# Altium schematic sheet RF_sheet.SchDoc
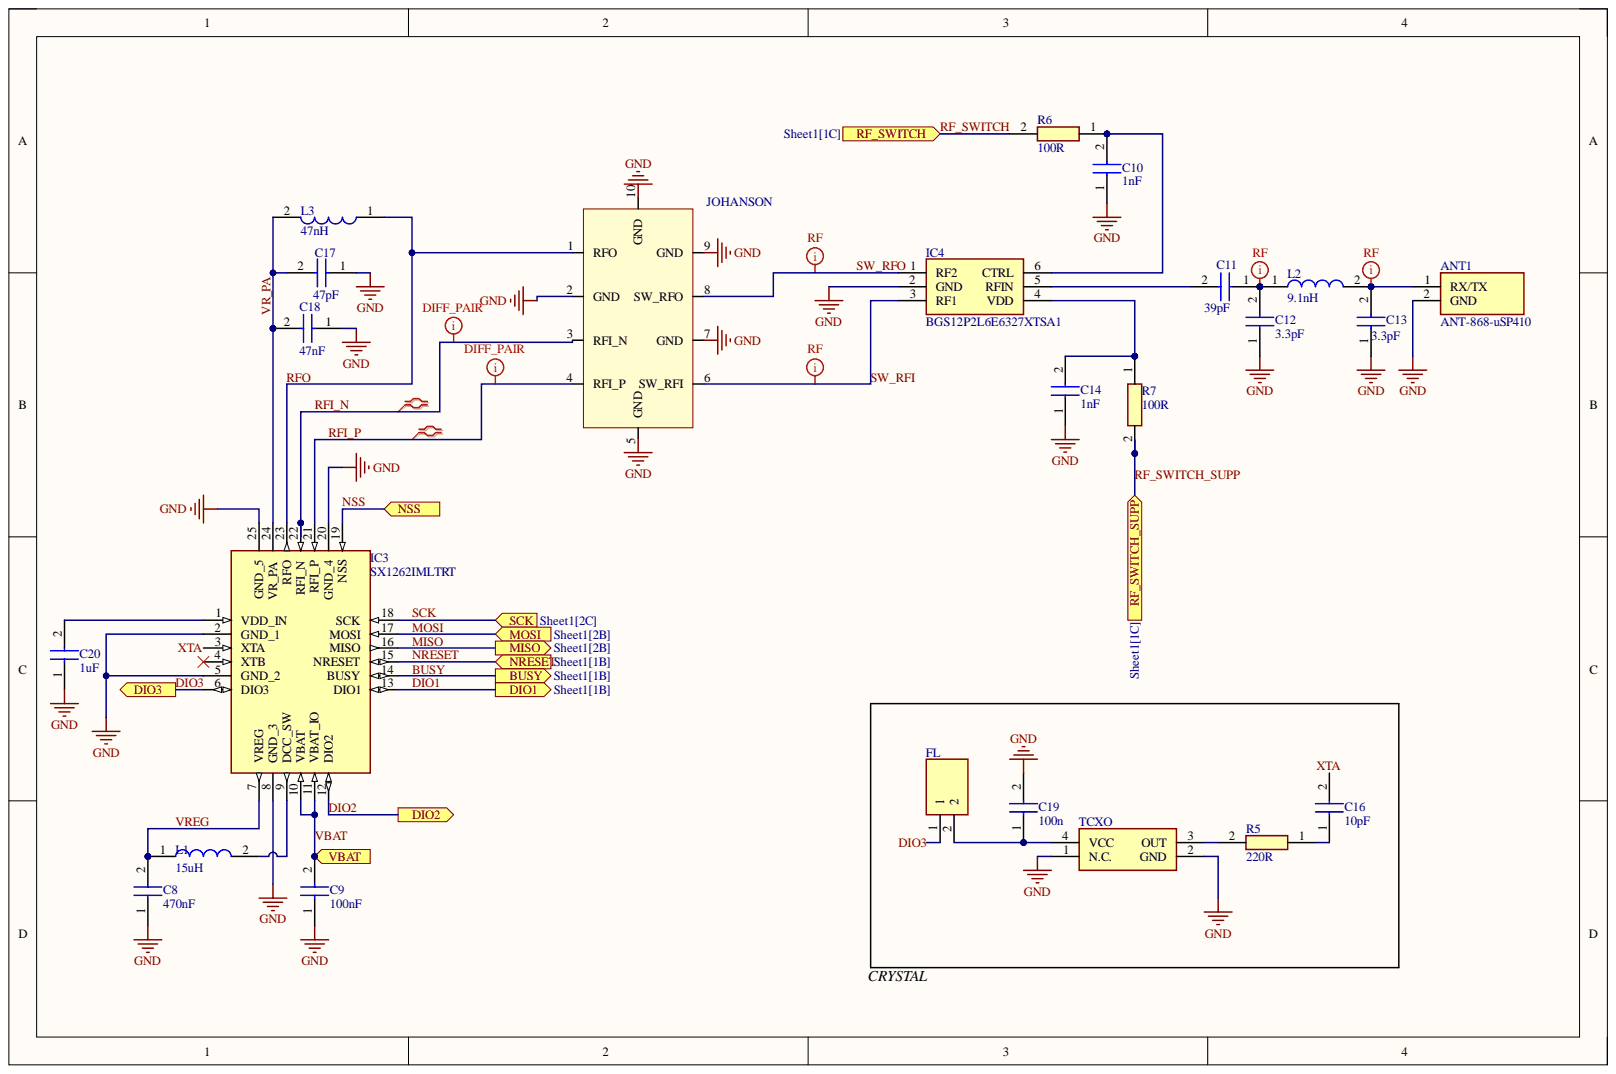
[diagram p0: RF_sheet.SchDoc]

## Parts
ANT1  ANT-868-uSP410  [ANT868uSP410]
C8  470nF  [CAPC1005X55N]
C9  100nF  [CAPC1005X55N]
C10  1nF  [CAPC1005X55N]
C11  39pF  [CAPC1005X55N]
C12  3.3pF  [CAPC1005X55N]
C13  3.3pF  [CAPC1005X55N]
C14  1nF  [CAPC1005X55N]
C16  10pF  [CAPC1005X55N]
C17  47pF  [CAPC1005X55N]
C18  47nF  [CAPC1005X55N]
C19  100n  [CAPC1005X55N]
C20  1uF  [CAPC1608X90N]
FL  BLM18PG181SN1D  [BLM18AG102BH1D]  (value not drawn)
IC3  SX1262IMLTRT  [QFN50P400X400X100-25N-D]
IC4  BGS12P2L6E6327XTSA1  [BGS12P2L6E6327XTSA1]
JOHANSON  (no value)  [JOHANSON]
L1  15uH  [INDC1608X55N]
L2  9.1nH  [INDC1005X37N]
L3  47nH  [INDC1005X37N]
R5  220R  [RESC1005X35N]
R6  100R  [RESC1005X35N]
R7  100R  [RESC1005X35N]
TCXO  TG2520SMN_32.0000M-ECGNNM0  [TG2520SMN320000MECGNNM0]  (value not drawn)

## Ports
BUSY, DIO1, DIO2, DIO3, MISO, MOSI, NRESET, NSS, RF_SWITCH, RF_SWITCH_SUPP, SCK, VBAT

## Nets
GND: ANT1.2 C8.1 C9.1 C10.1 C12.1 C13.1 C14.1 C17.1 C18.1 C19.2 C20.1 IC3.2(GND_1) IC3.5(GND_2) IC3.8(GND_3) IC3.20(GND_4) IC3.25(GND_5) IC4.2(GND) JOHANSON.2(GND) JOHANSON.5(GND) JOHANSON.7(GND) JOHANSON.9(GND) JOHANSON.10(GND) TCXO.1(N.C.) TCXO.2(GND)
BUSY: IC3.14(BUSY) ; port BUSY
DIO1: IC3.13(DIO1) ; port DIO1
DIO2: IC3.12(DIO2) ; port DIO2
DIO3: FL.1 IC3.6(DIO3) ; port DIO3
MISO: IC3.16(MISO) ; port MISO
MOSI: IC3.17(MOSI) ; port MOSI
NRESET: IC3.15(NRESET) ; port NRESET
NSS: IC3.19(NSS) ; port NSS
RF_SWITCH: R6.2 ; port RF_SWITCH
RF_SWITCH_SUPP: R7.2 ; port RF_SWITCH_SUPP
RFI_N: IC3.22(RFI_N) JOHANSON.3(RFI_N)
RFI_P: IC3.21(RFI_P) JOHANSON.4(RFI_P)
RFO: IC3.23(RFO) JOHANSON.1(RFO) L3.1
SCK: IC3.18(SCK) ; port SCK
SW_RFI: IC4.3(RF1) JOHANSON.6(SW_RFI)
SW_RFO: IC4.1(RF2) JOHANSON.8(SW_RFO)
VBAT: C9.2 IC3.10(VBAT) IC3.11(VBAT_IO) ; port VBAT
VR_PA: C17.2 C18.2 IC3.24(VR_PA) L3.2
VREG: C8.2 IC3.7(VREG) L1.1
XTA: C16.2 IC3.3(XTA)
NetANT1_1: ANT1.1 C13.2 L2.2
NetC10_2: C10.2 IC4.6(CTRL) R6.1
NetC11_1: C11.1 C12.2 L2.1
NetC11_2: C11.2 IC4.5(RFIN)
NetC14_2: C14.2 IC4.4(VDD) R7.1
NetC16_1: C16.1 R5.1
NetC19_1: C19.1 FL.2 TCXO.4(VCC)
NetC20_2: C20.2 IC3.1(VDD_IN)
NetIC3_9: IC3.9(DCC_SW) L1.2
NetR5_2: R5.2 TCXO.3(OUT)

Not connected: IC3 4(XTB)

## Library data of the parts
ANT1 = ANT-868-uSP410: Antenna; Manufacturer_Name: Linx Technologies; Component Kind: Standard; Datasheet Link: https://www.mouser.fr/datasheet/2/238/ant_868_usp410_ds-1892243.pdf; Height: 2.9mm; Manufacturer_Part_Number: ANT-868-uSP410; Mouser Part Number: 712-ANT-868-USP410; Mouser Price/Stock: https://www.mouser.co.uk/ProductDetail/Linx-Technologies/ANT-868-uSP410?qs=hWgE7mdIu5R%252BzZ8bzGhbRQ%3D%3D
C8-C14 C16-C19 = GRM155R61A224KE19D: 0402 Capacitor; Manufacturer_Name: Murata Electronics; Arrow Part Number: GRM155R61A224KE19D; Arrow Price/Stock: https://www.arrow.com/en/products/grm155r61a224ke19d/murata-manufacturing?region=nac; Component Kind: Standard; Datasheet Link: https://datasheet.datasheetarchive.com/originals/distributors/Datasheets_SAMA/ec4f1577dddcc7a13ddad897a28002d3.pdf; Height: 0.55mm; Manufacturer_Part_Number: GRM155R61A224KE19D; Mouser Part Number: 81-GRM155R61A224KE19; Mouser Price/Stock: https://www.mouser.co.uk/ProductDetail/Murata-Electronics/GRM155R61A224KE19D?qs=5bAuhL3RhmP2%252BUJqdGBuag%3D%3D
C20 = 06035C101K4T2A: 0603 Capacitor; Manufacturer_Name: AVX; Component Kind: Standard; Datasheet Link: https://componentsearchengine.com/Datasheets/1/06031A100FAT2A.pdf; Height: 0.9mm; Manufacturer_Part_Number: 06035C101K4T2A; Mouser Part Number: 581-06035C101K4T2A; Mouser Price/Stock: https://www.mouser.co.uk/ProductDetail/AVX/06035C101K4T2A/?qs=%252Bwax1qqoKK9kpvNfpp2NFA%3D%3D
FL = BLM18PG181SN1D: Filter; Manufacturer_Name: Murata Electronics; Arrow Part Number: BLM18PG181SN1D; Arrow Price/Stock: https://www.arrow.com/en/products/blm18pg181sn1d/murata-manufacturing?region=nac; Component Kind: Standard; Datasheet Link: https://www.murata.com/en-us/products/productdetail?partno=BLM18PG181SN1%23; Height: 0.95mm; Manufacturer_Part_Number: BLM18PG181SN1D; Mouser Part Number: 81-BLM18PG181SN1D; Mouser Price/Stock: https://www.mouser.co.uk/ProductDetail/Murata-Electronics/BLM18PG181SN1D?qs=sTaz8HHMol3W3rq0NJIneg%3D%3D
IC3 = SX1262IMLTRT: Integrated Circuit; Manufacturer_Name: SEMTECH; Arrow Part Number: SX1262IMLTRT; Arrow Price/Stock: https://www.arrow.com/en/products/sx1262imltrt/semtech?region=nac; Component Kind: Standard; Datasheet Link: http://www.mouser.com/datasheet/2/761/DS_SX1261-2_V1.1-1307803.pdf; Height: 1mm; Manufacturer_Part_Number: SX1262IMLTRT; Mouser Part Number: 947-SX1262IMLTRT; Mouser Price/Stock: https://www.mouser.co.uk/ProductDetail/Semtech/SX1262IMLTRT?qs=XFmntM7Yc9eYt6yxTPughQ%3D%3D
IC4 = BGS12P2L6E6327XTSA1: Integrated Circuit; Manufacturer_Name: Infineon; Arrow Part Number: BGS12P2L6E6327XTSA1; Arrow Price/Stock: https://www.arrow.com/en/products/bgs12p2l6e6327xtsa1/infineon-technologies-ag?region=nac; Component Kind: Standard; Datasheet Link: https://www.arrow.com/en/products/bgs12p2l6e6327xtsa1/infineon-technologies-ag; Height: 0.32mm; Manufacturer_Part_Number: BGS12P2L6E6327XTSA1; Mouser Part Number: 726-BGS12P2L6E6327XT; Mouser Price/Stock: https://www.mouser.co.uk/ProductDetail/Infineon-Technologies/BGS12P2L6E6327XTSA1?qs=mAH9sUMRCtuiUSQTWc4Zyg%3D%3D
JOHANSON = Component_2: Component Kind: Standard; Library Name: Schlib2_Custom.SchLib
L1 = ATFC-0603-22N-FT: Inductor; Manufacturer_Name: ABRACON; Component Kind: Standard; Datasheet Link: https://datasheet.datasheetarchive.com/originals/distributors/Datasheets-DGA11/2214358.pdf; Height: 0.55mm; Manufacturer_Part_Number: ATFC-0603-22N-FT; Mouser Part Number: 815-ATFC-0603-22NF; Mouser Price/Stock: https://www.mouser.com/Search/Refine.aspx?Keyword=815-ATFC-0603-22NF
L2 L3 = ATFC-0402-0N2-B-T: Inductor; Manufacturer_Name: ABRACON; Component Kind: Standard; Datasheet Link: http://www.mouser.com/ds/2/3/ATFC-0402-6001.pdf; Height: 0.37mm; Manufacturer_Part_Number: ATFC-0402-0N2-B-T; Mouser Part Number: 815-ATFC-0402-0N2B; Mouser Price/Stock: https://www.mouser.co.uk/ProductDetail/ABRACON/ATFC-0402-0N2-B-T?qs=nwAb7ZGSLg5V%252BGnp0AyMzg%3D%3D
R5-R7 = CPF0402B107KE1: 0402 Resistor; Manufacturer_Name: TE Connectivity; Component Kind: Standard; Datasheet Link: http://www.farnell.com/datasheets/1786775.pdf; Height: 0.35mm; Manufacturer_Part_Number: CPF0402B107KE1; Mouser Part Number: 279-CPF0402B107KE1; Mouser Price/Stock: https://www.mouser.co.uk/ProductDetail/TE-Connectivity-Neohm/CPF0402B107KE1?qs=CXI0wBT1M2hGHjTlP2dotA%3D%3D
TCXO = TG2520SMN_32.0000M-ECGNNM0: 32MHz TCXO; Manufacturer_Name: Epson Timing; Manufacturer_Part_Number: TG2520SMN 32.0000M-ECGNNM0; Component Kind: Standard; Datasheet Link: https://support.epson.biz/td/api/doc_check.php?dl=brief_TG2520SMN&lang=en; Height: 0.8mm
